# Altium schematic sheet CAN.SchDoc(CAN)
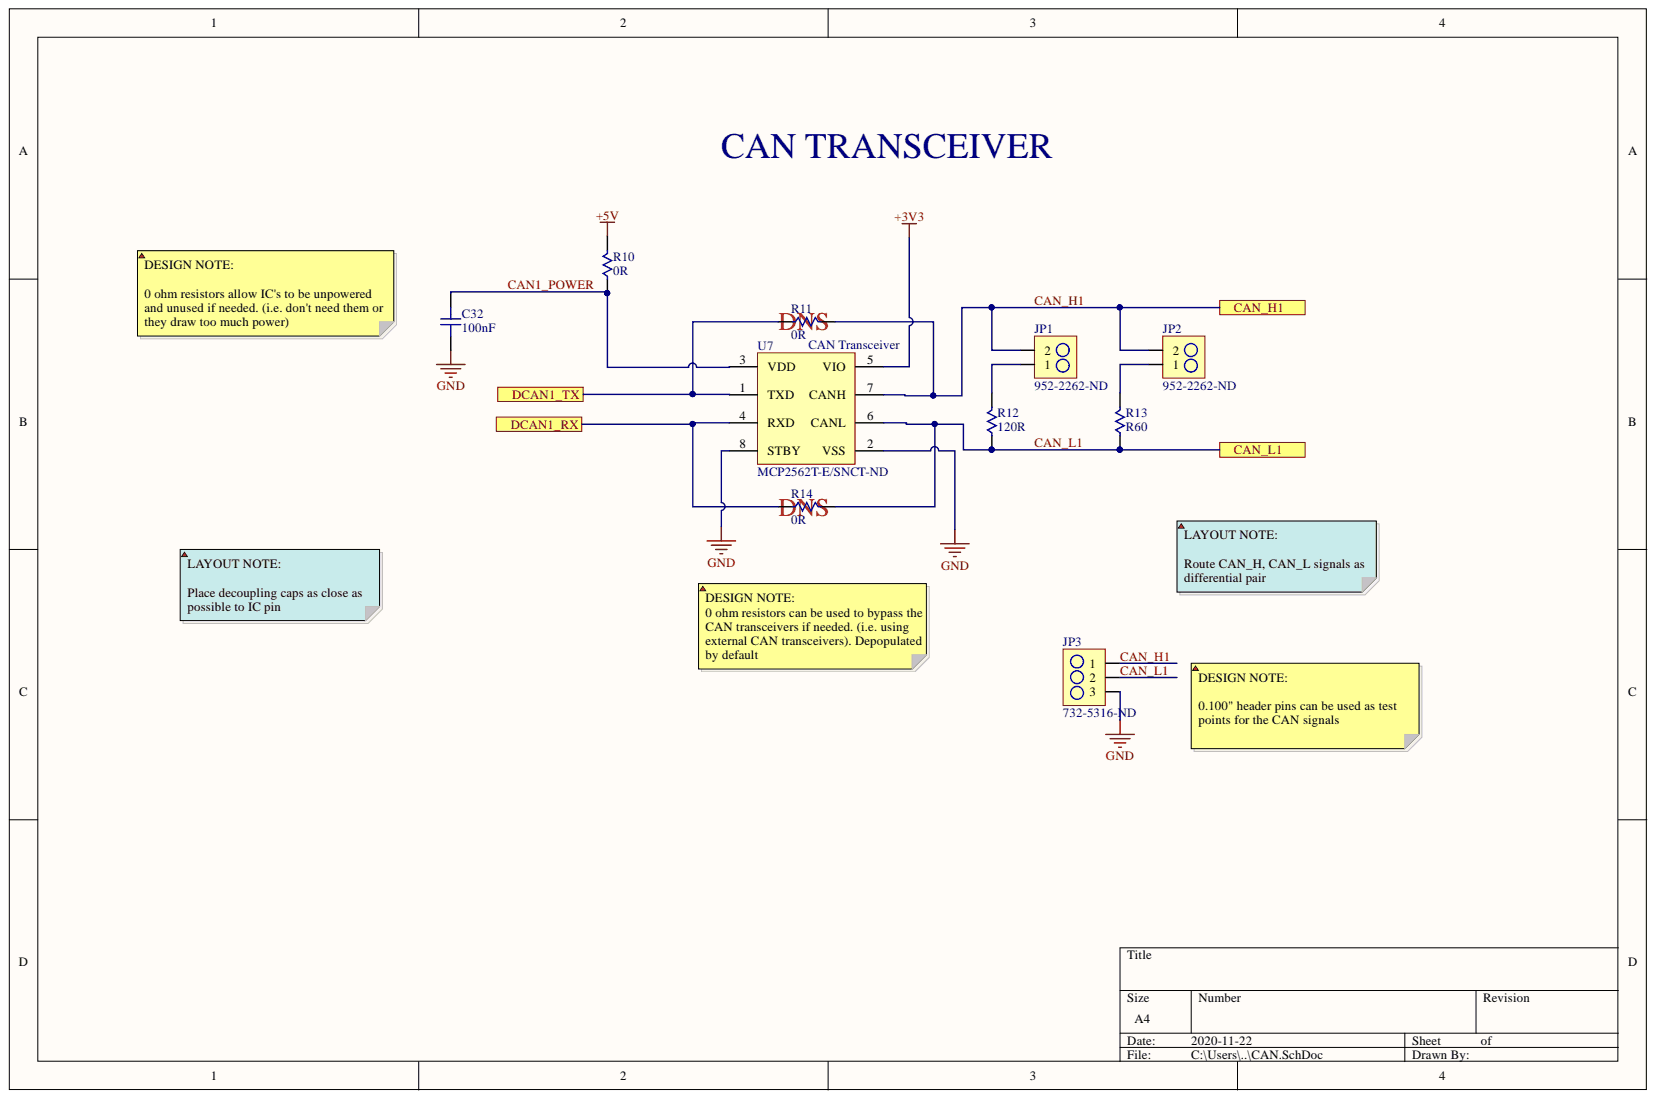
[diagram p0: CAN.SchDoc]

## Parts
C32  100nF  [C0402]
JP1  952-2262-ND  [2PIN_HEADER]
JP2  952-2262-ND  [2PIN_HEADER]
JP3  732-5316-ND  [3PIN_HEADER]
R10  0R  [R0402]
R11  0R  [R0402]
R12  120R  [R0402]
R13  R60  [R0402]
R14  0R  [R0402]
U7  MCP2562T-E/SNCT-ND  [8-SOIC w/o THRM]

## Ports
CAN_H1, CAN_L1, DCAN1_RX, DCAN1_TX

## Nets
+3V3: U7.5(VIO)
+5V: R10.2
GND: C32.1 JP3.3 U7.2(VSS) U7.8(STBY)
CAN1_POWER: C32.2 R10.1 U7.3(VDD)
CAN_H1: JP1.2 JP2.2 JP3.1 R11.2 U7.7(CANH) ; port CAN_H1
CAN_L1: JP3.2 R12.1 R13.1 R14.2 U7.6(CANL) ; port CAN_L1
DCAN1_RX: R14.1 U7.4(RXD) ; port DCAN1_RX
DCAN1_TX: R11.1 U7.1(TXD) ; port DCAN1_TX
NetJP1_1: JP1.1 R12.2
NetJP2_1: JP2.1 R13.2

## Library data of the parts
C32 = CL05A104MP5NNNC: CAP MLCC 0.1uF 10V X5R 0402 20% SMD 0402 85C Paper T/R; Manufacturer: Samsung; Width: 0.02inch; Voltage Rating (DC): 10V; Voltage Rating: 10V; Tolerance: 20%; Thickness: 0.022inch; Supplier Part Number 1: 1276-1443-1-ND; Supplier 1: Digi-Key; Stock 1: 100000; Radiation Hardening: No; Pricing 1: 1=0.1, 10=0.024, 100=0.0106, 500=0.0076, 1000=0.006, 2500=0.0054, 5000=0.005 (USD); Packaging: Tape and Reel; Mount: Surface Mount; Min Operating Temperature: -55°C; Max Operating Temperature: 85°C; Manufacturer Part Number: CL05A104MP5NNNC; Length: 1mm; Lead Free: Lead Free; Height: 0.5mm; Dielectric: X5R; Depth: 0.5mm; ComponentLink1URL: https://media.digikey.com/pdf/Data%20Sheets/Samsung%20PDFs/CL_Series_MLCC_ds.pdf; ComponentLink1Description: https://media.digikey.com/pdf/Data%20Sheets/Samsung%20PDFs/CL_Series_MLCC_ds.pdf; Component Kind: Standard; Case Code (Metric): 1005; Case Code (Imperial): 0402; Case/Package: 0402; Capacitance: 100nF; Library Name: PHANTOM_LIBRARY.SchLib
JP1 JP2 = M20-9990246: CONN_HeaderPins2pos Headers & Wire Housings 02 SIL VERTICAL PIN HEADER TIN; Manufacturer: Harwin; Voltage Rating (AC): 750V; Pitch: 2.54mm; Number of Positions: 2; Max Current Rating: 3A; Insulation Resistance: 10GR; Gender: Male; Contact Resistance: 30mR; Connector Type: Male Pin; Termination: Solder; Supplier Part Number 1: 952-2262-ND; Supplier 1: Digi-Key; Stock 1: 53577; REACH SVHC: No SVHC; Radiation Hardening: No; Pricing 1: 1=0.11, 10=0.099, 100=0.0609, 500=0.0504, 1000=0.0441, 5000=0.0378, 10000=0.0368 (USD); Packaging: Bulk; Package Quantity: 1000; Orientation: Straight; Number of Rows: 1; Number of Contacts: 2; Min Operating Temperature: -40°C; Max Operating Temperature: 105°C; Manufacturer Part Number: M20-9990246; Length: 5.08mm; Housing Material: Nylon; Housing Colour: Black; Depth: 2.54mm; Current Rating: 3A; Contact Plating: Tin; Contact Mating Length: 0.24inch; Contact Material: Bronze,Brass; ComponentLink5URL: https://datasheet.ciiva.com/26852/getdatasheetpartid-384170-26852203.pdf; ComponentLink5Description: https://datasheet.ciiva.com/26852/getdatasheetpartid-384170-26852203.pdf; ComponentLink4URL: https://datasheet.ciiva.com/22660/1830818-22660571.pdf; ComponentLink4Description: https://datasheet.ciiva.com/22660/1830818-22660571.pdf; ComponentLink3URL: https://datasheet.ciiva.com/11745/0900766b81034e61-11745755.pdf; ComponentLink3Description: https://datasheet.ciiva.com/11745/0900766b81034e61-11745755.pdf; ComponentLink2URL: https://datasheet.ciiva.com/6833/m20-999-6833368.pdf; ComponentLink2Description: https://datasheet.ciiva.com/6833/m20-999-6833368.pdf; ComponentLink1URL: https://datasheet.ciiva.com/8046/315376-8046930.pdf; ComponentLink1Description: https://datasheet.ciiva.com/8046/315376-8046930.pdf; Component Kind: Standard; Colour: Black; Case/Package: SIL; Library Name: PHANTOM_LIBRARY.SchLib
JP3 = 61300311121: CONN_HeaderPins3pos WURTH ELEKTRONIK Board-To-Board Connector, Vertical, WR-PHD Series, Through Hole, Header, 3 Contacts, 2.54 mm; Manufacturer: Wurth Electronics; Pitch: 0.1inch; Number of Positions: 3; Gender: Male; Connector Type: Male Pin; Termination: Solder; Supplier Part Number 1: 732-5316-ND; Supplier 1: Digi-Key; Stock 1: 57026; REACH SVHC: Unknown; Pricing 1: 1=0.13, 10=0.106, 25=0.088, 50=0.074, 100=0.067, 1000=0.061 (USD); Packaging: Bulk; Number of Rows: 1; Number of Contacts: 3; Mount: Through Hole; Manufacturer Part Number: 61300311121; Lead Free: Lead Free; Contact Plating: Gold; Contact Mating Length: 0.236inch; Contact Material: Copper; ComponentLink1URL: http://www.farnell.com/cad/1737268.pdf; ComponentLink1Description: http://www.farnell.com/cad/1737268.pdf; Component Kind: Standard; Colour: Black; Case/Package: 100; Library Name: PHANTOM_LIBRARY.SchLib
R10 R11 R14 = RC0402JR-070RL: RES SMD 0 OHM 1/16W 0402; Manufacturer: Yageo; Voltage Rating: 50V; Tolerance: 5%; Temperature Coefficient: 200ppm/°C; Resistance: 0mR; Power Rating: 62.5mW; Max Power Dissipation: 63mW; Width: 0.02inch; Termination: SMD/SMT; Supplier Part Number 1: 311-0.0JRCT-ND; Supplier 1: Digi-Key; Stock 1: 44333412; REACH SVHC: Unknown; Radiation Hardening: No; Pricing 1: 1=0.1, 10=0.017, 25=0.0124, 50=0.0096, 100=0.007, 250=0.0054, 500=0.0043, 1000=0.0032, 5000=0.0023 (USD); Packaging: Tape and Reel; Package Quantity: 1; Number of Terminations: 2; Min Operating Temperature: -55°C; Military Standard: Not; Max Operating Temperature: 155°C; Manufacturer Part Number: RC0402JR-070RL; Length: 1mm; Lead Free: Lead Free; Height: 0.016inch; Features: Moisture Resistant; Depth: 0.5mm; Contact Plating: Matte,Tin; Composition: Thick Film; Component Kind: Standard; Case Code (Metric): 1005; Case Code (Imperial): 0402; Case/Package: 0402; Library Name: PHANTOM_LIBRARY.SchLib
R12 = RMCF0402JT120R: RES SMD 120 OHM 5% 0402 1/16W ±100ppm/C Molded SMD Paper T/R; Manufacturer: Stackpole Electronics; Temperature Coefficient: 100ppm/°C; Power Rating: 62.5mW; Max Power Dissipation: 63mW; Width: 0.02inch; Tolerance: 5%; Supplier Part Number 1: RMCF0402JT120RCT-ND; Supplier 1: Digi-Key; Stock 1: 112024; Resistance: 120R; Pricing 1: 1=0.1, 10=0.012, 100=0.005, 1000=0.0022, 2500=0.002, 5000=0.0016 (USD); Packaging: Cut Tape; Number of Terminations: 2; Mount: Surface Mount; Min Operating Temperature: -55°C; Max Operating Temperature: 155°C; Manufacturer Part Number: RMCF0402JT120R; Length: 0.039inch; Lead Free: Lead Free; Height: 0.016inch; Features: Automotive AEC-Q200; Contact Plating: Matte,Tin; Composition: Thick Film; Component Kind: Standard; Case Code (Metric): 1005; Case Code (Imperial): 0402; Case/Package: 0402; Library Name: PHANTOM_LIBRARY.SchLib
R13 = RMCF0402FT60R4: RES SMD 60.4 OHM 1% 0402 1/16W 100ppm/C Molded SMD SMD Paper T/R; Manufacturer: Stackpole Electronics; Temperature Coefficient: 100ppm/°C; Resistance: 60.4R; Max Power Dissipation: 63mW; Width: 0.02inch; Tolerance: 1%; Supplier Part Number 1: RMCF0402FT60R4CT-ND; Supplier 1: Digi-Key; Stock 1: 29830; Radiation Hardening: No; Pricing 1: 1=0.1, 10=0.016, 100=0.0064, 1000=0.0029, 2500=0.0025, 5000=0.0021 (USD); Packaging: Tape and Reel; Number of Terminations: 2; Mount: Surface Mount; Min Operating Temperature: -55°C; Max Operating Temperature: 155°C; Manufacturer Part Number: RMCF0402FT60R4; Length: 0.039inch; Lead Free: Lead Free; Height: 0.016inch; Features: Automotive AEC-Q200; Contact Plating: Matte,Tin; Composition: Thick Film; Component Kind: Standard; Case Code (Metric): 1005; Case Code (Imperial): 0402; Case/Package: 0402; Library Name: PHANTOM_LIBRARY.SchLib
U7 = CAN TRAN-MCP2562T-E/SN: IC TRANSCEIVER HALF 1/1 8SOIC; Manufacturer: Microchip; Turn-On Delay Time: 125ns; Receiver Hysteresis: 200mV; Number of Transceivers: 1; Nominal Supply Current: 85uA; Min Supply Voltage: 4.5V; Max Supply Voltage: 5.5V; Data Rate: 1Mbps; Supplier Part Number 1: MCP2562T-E/SNDKR-ND; Supplier 1: Digi-Key; Stock 1: 0; Radiation Hardening: No; Propagation Delay: 125ns; Pricing 1: 1=0.9, 25=0.75, 100=0.68 (USD); Packaging: Tape and Reel; Package Quantity: 3300; Number of Pins: 8; Mount: Surface Mount; Min Operating Temperature: -40°C; Max Operating Temperature: 125°C; Manufacturer Part Number: MCP2562T-E/SN; Library Name: ICs.SchLib; Lead Free: Lead Free; ComponentLink2URL: http://ww1.microchip.com/downloads/en/DeviceDoc/25167B.pdf?src-supplier=Avnet; ComponentLink2Description: http://ww1.microchip.com/downloads/en/DeviceDoc/25167B.pdf?src-supplier=Avnet; ComponentLink1URL: http://ww1.microchip.com/downloads/en/DeviceDoc/25167B.pdf?src-supplier=Digi-Key; ComponentLink1Description: http://ww1.microchip.com/downloads/en/DeviceDoc/25167B.pdf?src-supplier=Digi-Key; Component Kind: Standard; Case/Package: SOIC
